# Altium schematic sheet Top.SchDoc(U_Top)
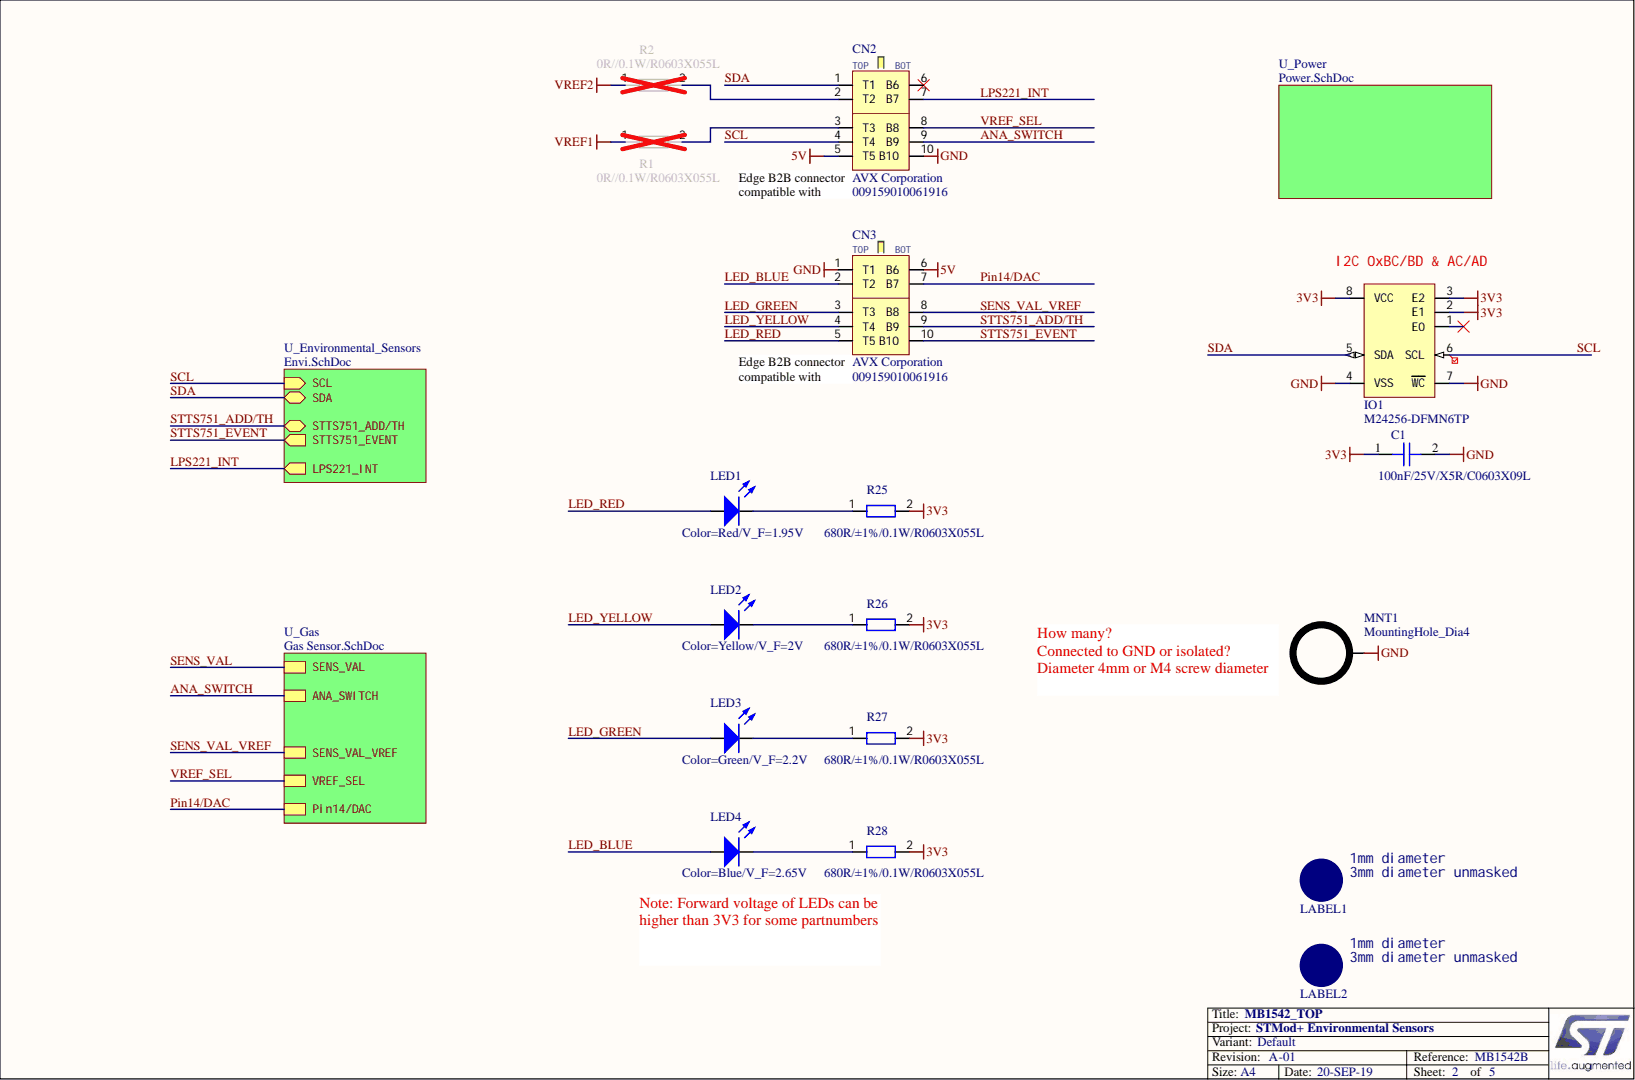
[diagram p0: Top.SchDoc]

## Parts
C1  100nF/25V/X5R/C0603X09L  [C0603X09L]
CN2  AVX Corporation_009159010061916  Manufacturer Order Code: 009159010061916  Manufacturer: AVX Corporation  [AVX-009159010061916_DEVICE]  (no BOM)
CN3  AVX Corporation_009159010061916  Manufacturer Order Code: 009159010061916  Manufacturer: AVX Corporation  [AVX-009159010061916_DEVICE]  (no BOM)
IO1  M24256-DFMN6TP  [ST_SO8]
LABEL1  (no value)  [fiducial_1.0mm]
LABEL2  (no value)  [fiducial_1.0mm]
LED1  Color=Red/V_F=1.95V  [LED_SMD_0603_110_RECT_FLAT_RED]
LED2  Color=Yellow/V_F=2V  [LED_SMD_0603_110_RECT_FLAT_YELLOW]
LED3  Color=Green/V_F=2.2V  [LED_SMD_0603_110_RECT_FLAT_GREEN]
LED4  Color=Blue/V_F=2.65V  [LED_SMD_0603_110_RECT_FLAT_BLUE]
MNT1  MountingHole_Dia4  [MountingHole_Dia4]  (no BOM)
R1  0R//0.1W/R0603X055L  [R0603X055L]
R2  0R//0.1W/R0603X055L  [R0603X055L]
R25  680R/±1%/0.1W/R0603X055L  [R0603X055L]
R26  680R/±1%/0.1W/R0603X055L  [R0603X055L]
R27  680R/±1%/0.1W/R0603X055L  [R0603X055L]
R28  680R/±1%/0.1W/R0603X055L  [R0603X055L]

## Nets
3V3: C1.1 IO1.2(E1) IO1.3(E2) IO1.8(VCC) R25.2 R26.2 R27.2 R28.2
5V: CN2.5(T5) CN3.6(B6)
GND: C1.2 CN2.10(B10) CN3.1(T1) IO1.4(VSS) IO1.7(WC) MNT1.1
VREF1: R1.1
VREF2: R2.1
ANA_SWITCH: CN2.9(B9)
LED_BLUE: CN3.2(T2) LED4.A
LED_GREEN: CN3.3(T3) LED3.A
LED_RED: CN3.5(T5) LED1.A
LED_YELLOW: CN3.4(T4) LED2.A
LPS221_INT: CN2.7(B7)
Pin14/DAC: CN3.7(B7)
SCL: CN2.4(T4) IO1.6(SCL)
SDA: CN2.1(T1) IO1.5(SDA)
SENS_VAL_VREF: CN3.8(B8)
STTS751_ADD/TH: CN3.9(B9)
STTS751_EVENT: CN3.10(B10)
VREF_SEL: CN2.8(B8)
NetCN2_2: CN2.2(T2) R2.2
NetCN2_3: CN2.3(T3) R1.2
NetCN2_6: CN2.6(B6)
NetIO1_1: IO1.1(E0)
NetLED1_K: LED1.K R25.1
NetLED2_K: LED2.K R26.1
NetLED3_K: LED3.K R27.1
NetLED4_K: LED4.K R28.1
SENS_VAL:

## Library data of the parts
C1 = Capacitor_C_generic_100nF_25V_X5R_C0603X09L_STD__GRM188R61E104KA01: 100nF/25V/X5R/C0603; Value: 100nF; Tolerance: ±10%; Temp Min [DegC]: -55; Temp Max [DegC]: 85; SMT Assembly: YES; PkgAssyCode: C0603; Grade: STD; DielectricMaterial: X5R; DCRated: 25V; Database Table Name: c_smd_0603; Supplier Part Number 1: 81-GRM188R61E104KA1D; Supplier 4: Farnell; Supplier 3: Arrow; Supplier 2: Digi-Key; Supplier 1: Mouser; Status: new; RoHS: YES-GbD; Pin Count: 2; Part Number: Capacitor_C_generic_100nF_25V_X5R_C0603X09L_STD__GRM188R61E104KA01; Package: C0603X09L; Mounting: SMT; Manufacturer_Part_Number: 100nF/25V/X5R/C0603; Manufacturer: Generic; Footprint Class: IPC_High; ComponentLink1URL: http://www.murata.com/products/catalog/pdf/c02e.pdf; ComponentLink1Description: Datasheet; Component Kind: Standard; Component Class: Capacitor; Library Name: nas_mysql_lib.DbLib
CN2 CN3 = Edge Connector_Header 5X2_EDGE_12-345_10_Plug_AVX-009159010061916_DEVICE__NONE____AVX Corporation009159010061916: Edge Connector, 10-Pin, Dual row; Manufacturer Series: 9159; VoltageRating: 300V; SMT Assembly: NO; NumberOfRows: 2; NumberOfContacts: 10; Gender: Plug; Database Table Name: cn; CurrentRating: 2.5A; Supplier 4: Farnell; Supplier 3: Arrow; Supplier 2: Digi-Key; Supplier 1: Mouser; Status: new; RoHS: YES-GbFab; Pin Count: 10; Part Number: Edge Connector_Header 5X2_EDGE_12-345_10_Plug_AVX-009159010061916_DEVICE__NONE____AVX Corporation009159010061916; Mounting: NONE; Footprint Class: IPC_High; ComponentLink1URL: http://datasheets.avx.com/OpenEndedCard%20Edge_00-9159.pdf; ComponentLink1Description: Datasheet; Component Class: Edge Connector; Library Name: nas_mysql_lib.DbLib
IO1 = EEPROM_ST_M24256-DFMN6TP_: Manufacturer: ST; Temp Min [DegC]: -40; Temp Max [DegC]: 85; SMT Assembly: YES; Grade: STD; Database Table Name: io; Supplier Part Number 2: 497-15743-1-ND; Supplier 4: Farnell; Supplier 3: Arrow; Supplier 2: Digi-Key; Supplier 1: Mouser; Status: new; RoHS: YES-GbD; PkgAssyCode: ST_SO8; Pin Count: 8; Partnumber: M24256-DFMN6TP; Part Number: EEPROM_ST_M24256-DFMN6TP_; Package: ST_SO8; Mounting: SMT; Manufacturer_Part_Number: M24256-DFMN6TP; Footprint Class: IPC_High; Description: M24256-DFMN6TP; ComponentLink1URL: https://www.st.com/content/ccc/resource/technical/document/datasheet/59/05/c9/5b/7b/41/48/b6/CD00259167.pdf/files/CD00259167.pdf/jcr:content/translations/en.CD00259167.pdf; ComponentLink1Description: Datasheet; Component Kind: Standard; Component Class: EEPROM; Library Name: nas_mysql_lib.DbLib
LABEL1 LABEL2 = Fiducial_1mm_circular: SMT Assembly: NO; Database Table Name: fabric; Status: new; RoHS: YES; Pin Count: 1; Part Number: Fiducial_1mm_circular; Package: fiducial_1.0mm; Mounting: NONE; Footprint Class: STD; Component Kind: Standard; Component Class: Fiducial; Library Name: nas_mysql_lib.DbLib
LED1 = LED_LED_generic__Red_1.95V_LED_SMD_0603_110_RECT_FLAT_RED_STD__Kingbright_APT1608SURCK: Wavelength - Peak: 645nm; Wavelength - Dominant: 630nm; ViewingAngle: 120°; V_F: 1.95V; SMT Assembly: YES; Rating mcd: 80mcd; Lens Transparency: Clear; Lens StyleSize: Rectangle with Flat Top; 1.20mm x 0.80mm; Lens Color: Colorless; Grade: STD; Color: Red; Supplier Part Number 2: 754-1123-1-ND; Supplier Part Number 1: 604-APT1608SURCK; Supplier 4: Farnell; Supplier 3: Arrow; Supplier 2: Digi-Key; Supplier 1: Mouser; Status: new; RoHS: YES-GbD; PkgAssyCode: LED_SMD_0603_110_RECT_FLAT_RED; Pin Count: 2; Part Number: LED_LED_generic__Red_1.95V_LED_SMD_0603_110_RECT_FLAT_RED_STD__Kingbright_APT1608SURCK; Mounting: SMT; Manufacturer Order Code: APT1608SURCK; Manufacturer: Kingbright; Footprint Class: IPC_High; Database Table Name: led_smd; ComponentLink1URL: http://www.kingbrightusa.com/images/catalog/SPEC/APT1608SURCK.pdf; ComponentLink1Description: Datasheet; Component Kind: Standard; Component Class: LED; Library Name: nas_mysql_lib.DbLib
LED2 = LED_LED_generic__Yellow_2V_LED_SMD_0603_110_RECT_FLAT_YELLOW_STD__Kingbright_APT1608SYCK: Wavelength - Peak: 590nm; ViewingAngle: 120°; V_F: 2V; SMT Assembly: YES; Rating mcd: 150mcd; Lens Transparency: Clear; Lens StyleSize: Rectangle with Flat Top; 1.20mm x 0.80mm; Lens Color: Colorless; Grade: STD; Color: Yellow; Wavelength - Dominant: 590nm; Supplier Part Number 2: 754-1124-1-ND; Supplier Part Number 1: 604-APT1608SYCK; Supplier 4: Farnell; Supplier 3: Arrow; Supplier 2: Digi-Key; Supplier 1: Mouser; Status: new; RoHS: YES-GbD; PkgAssyCode: LED_SMD_0603_110_RECT_FLAT_YELLOW; Pin Count: 2; Part Number: LED_LED_generic__Yellow_2V_LED_SMD_0603_110_RECT_FLAT_YELLOW_STD__Kingbright_APT1608SYCK; Mounting: SMT; Manufacturer Order Code: APT1608SYCK; Manufacturer: Kingbright; Footprint Class: IPC_High; Database Table Name: led_smd; ComponentLink1URL: http://www.kingbrightusa.com/images/catalog/SPEC/APT1608SYCK.pdf; ComponentLink1Description: Datasheet; Component Kind: Standard; Component Class: LED; Library Name: nas_mysql_lib.DbLib
LED3 = LED_LED_generic__Green_2.2V_LED_SMD_0603_110_RECT_FLAT_GREEN_STD__Kingbright_APT1608SGC: Wavelength - Peak: 565nm; Wavelength - Dominant: 568nm; ViewingAngle: 120°; V_F: 2.2V; SMT Assembly: YES; Rating mcd: 12mcd; Lens Transparency: Clear; Lens StyleSize: Rectangle with Flat Top; 1.20mm x 0.80mm; Lens Color: Colorless; Grade: STD; Color: Green; Supplier Part Number 2: 754-1121-1-ND; Supplier Part Number 1: 604-APT1608SGC; Supplier 4: Farnell; Supplier 3: Arrow; Supplier 2: Digi-Key; Supplier 1: Mouser; Status: new; RoHS: YES-GbD; PkgAssyCode: LED_SMD_0603_110_RECT_FLAT_GREEN; Pin Count: 2; Part Number: LED_LED_generic__Green_2.2V_LED_SMD_0603_110_RECT_FLAT_GREEN_STD__Kingbright_APT1608SGC; Mounting: SMT; Manufacturer Order Code: APT1608SGC; Manufacturer: Kingbright; Footprint Class: IPC_High; Database Table Name: led_smd; ComponentLink1URL: http://www.kingbrightusa.com/images/catalog/SPEC/APT1608SGC.pdf; ComponentLink1Description: Datasheet; Component Kind: Standard; Component Class: LED; Library Name: nas_mysql_lib.DbLib
LED4 = LED_LED_generic__Blue_2.65V_LED_SMD_0603_110_RECT_FLAT_BLUE_STD__Kingbright_APT1608LVBC/D: Manufacturer Series: HELI2; Wavelength - Peak: 465nm; Wavelength - Dominant: 470nm; ViewingAngle: 120°; V_F: 2.65V; SMT Assembly: YES; Rating mcd: 24mcd; Lens Transparency: Clear; Lens StyleSize: Rectangle with Flat Top; 1.10mm x 0.80mm; Lens Color: Colorless; Grade: STD; Color: Blue; Supplier Part Number 2: 754-1933-1-ND; Supplier Part Number 1: 604-APT1608LVBCD; Supplier 4: Farnell; Supplier 3: Arrow; Supplier 2: Digi-Key; Supplier 1: Mouser; Status: new; RoHS: YES-GbD; PkgAssyCode: LED_SMD_0603_110_RECT_FLAT_BLUE; Pin Count: 2; Part Number: LED_LED_generic__Blue_2.65V_LED_SMD_0603_110_RECT_FLAT_BLUE_STD__Kingbright_APT1608LVBC/D; Mounting: SMT; Manufacturer Order Code: APT1608LVBC/D; Manufacturer: Kingbright; Footprint Class: IPC_High; Database Table Name: led_smd; ComponentLink1URL: http://www.kingbrightusa.com/images/catalog/SPEC/APT1608LVBC-D.pdf; ComponentLink1Description: Datasheet; Component Kind: Standard; Component Class: LED; Library Name: nas_mysql_lib.DbLib
MNT1 = Mechanical_MountingHole_Dia4: SMT Assembly: NO; Status: new; RoHS: YES-GbFab; Pin Count: 1; Part Number: Mechanical_MountingHole_Dia4; Mounting: NONE; Footprint Class: IPC_High; Database Table Name: mechanical; Component Class: Mechanical; Library Name: nas_mysql_lib.DbLib
R1 R2 = Resistor_R_generic_0R__0.1W__R0603X055L_STD__YageoRC: Manufacturer Series: RC; Manufacturer Order Code: RC0603FR-070RL; Manufacturer: Yageo; Value: 0R; Temp Min [DegC]: -55; Temp Max [DegC]: 155; SMT Assembly: YES; PkgAssyCode: R0603; MaxPower: 0.1W; Grade: STD; Database Table Name: r_smd_0603; Supplier Part Number 2: 603-RC0603FR-070RL; Supplier Part Number 1: 311-0.0HRCT-ND; Supplier 4: Farnell; Supplier 3: Arrow; Supplier 2: Mouser; Supplier 1: Digi-Key; Status: new; RoHS: YES-GbD; Pin Count: 2; Part Number: Resistor_R_generic_0R__0.1W__R0603X055L_STD__YageoRC; Mounting: SMT; Footprint Class: IPC_High; ComponentLink1URL: http://www.yageo.com/documents/recent/PYu-RC_Group_51_RoHS_L_8.pdf; ComponentLink1Description: Datasheet; Component Kind: Standard; Component Class: Resistor; Library Name: nas_mysql_lib.DbLib
R25-R28 = Resistor_R_generic_680R_±1%_0.1W_±100ppm/DegC_R0603X055L_STD__YageoRC: Manufacturer Series: RC; Manufacturer Order Code: RC0603FR-07680RL; Manufacturer: Yageo; Value: 680R; Tolerance: ±1%; Temp Min [DegC]: -55; Temp Max [DegC]: 155; SMT Assembly: YES; PkgAssyCode: R0603; MaxPower: 0.1W; Grade: STD; Database Table Name: r_smd_0603; ThermalDrift: ±100ppm/DegC; Supplier Part Number 2: 603-RC0603FR-07680RL; Supplier Part Number 1: 311-680HRCT-ND; Supplier 4: Farnell; Supplier 3: Arrow; Supplier 2: Mouser; Supplier 1: Digi-Key; Status: new; RoHS: YES-GbD; Pin Count: 2; Part Number: Resistor_R_generic_680R_±1%_0.1W_±100ppm/DegC_R0603X055L_STD__YageoRC; Mounting: SMT; Footprint Class: IPC_High; ComponentLink1URL: http://www.yageo.com/documents/recent/PYu-RC_Group_51_RoHS_L_7.pdf; ComponentLink1Description: Datasheet; Component Kind: Standard; Component Class: Resistor; Library Name: nas_mysql_lib.DbLib
